# Altium schematic sheet CAN Transceiver SN65HVD232D.SchDoc
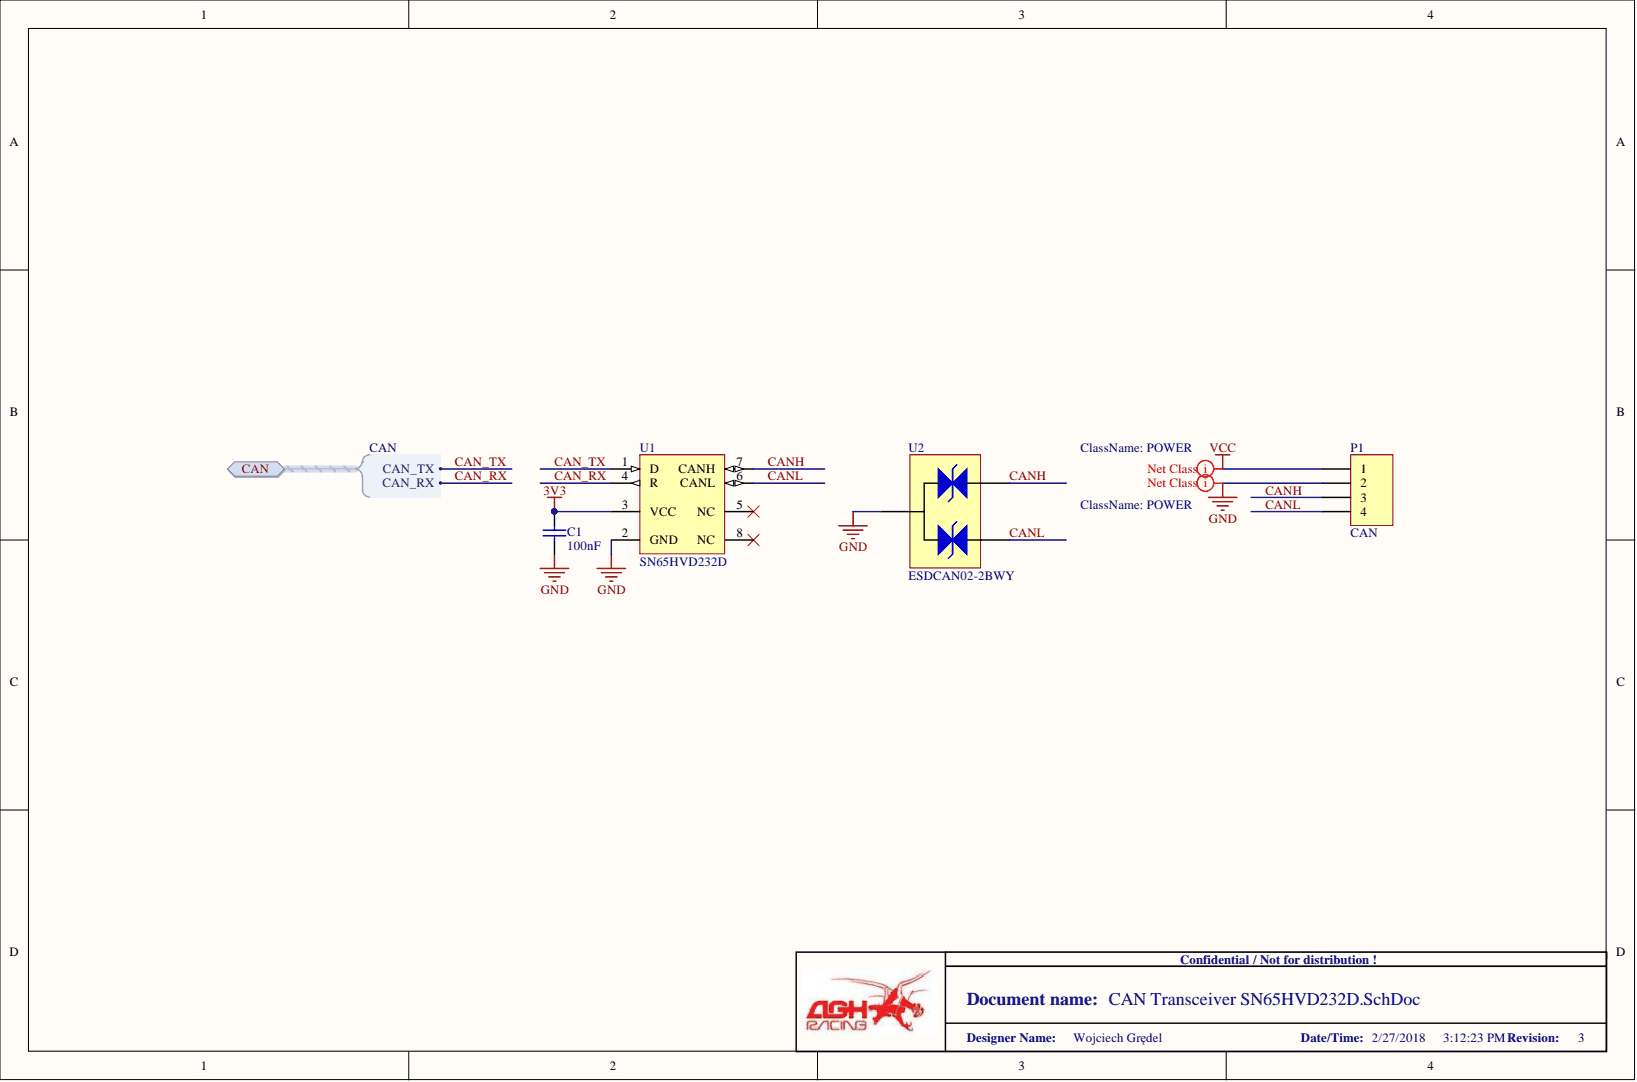
[diagram p0: CAN Transceiver SN65HVD232D.SchDoc]

## Parts
C1  value 100nF  [CAP_0603_IPC_MD]  (hidden comment: Cap)
P1  CAN  [WIRE_PADS_4]
U1  SN65HVD232D  [SOIC_8_IPC_MD]
U2  ESDCAN02-2BWY  [SOT23_IPC_MD]

## Ports
CAN, CAN.CAN_RX, CAN.CAN_TX

## Nets
3V3: C1.2 U1.3(VCC)
GND: C1.1 P1.2 U1.2(GND) U2.3
VCC: P1.1
CAN_RX: U1.4(R) ; port CAN
CAN_TX: U1.1(D) ; port CAN
CANH: P1.3 U1.7(CANH) U2.1
CANL: P1.4 U1.6(CANL) U2.2

Not connected: U1 5(NC) 8(NC)

## Library data of the parts
C1 = Cap: Capacitor; Component Kind: Standard; Library Name: agh_racing_2018.SchLib
P1 = Header 4: Header, 4-Pin; Component Kind: Standard; Library Name: agh_racing_2018.SchLib
U1 = SN65HVD232D: 3.3V CAN Transceiver with Standby Mode, 17 mA, -40 to 85 degC, 8-pin SOIC (D), Green (RoHS & no Sb/Br); Manufacturer: Texas Instruments; Component Kind: Standard; Manufacturer Part Number: SN65HVD232D; Mounting Technology: SMD; Part Number: SN65HVD232D; Supplier: TME; Supplier Part Number: SN65HVD232D; Type: IC; Library Name: agh_racing_2018.SchLib
U2 = ESDCAN02-2BWY: ESD CAN protection (2 transils); Component Kind: Standard; Library Name: agh_racing_2018.SchLib
